# Altium schematic sheet Accelerometer.SchDoc
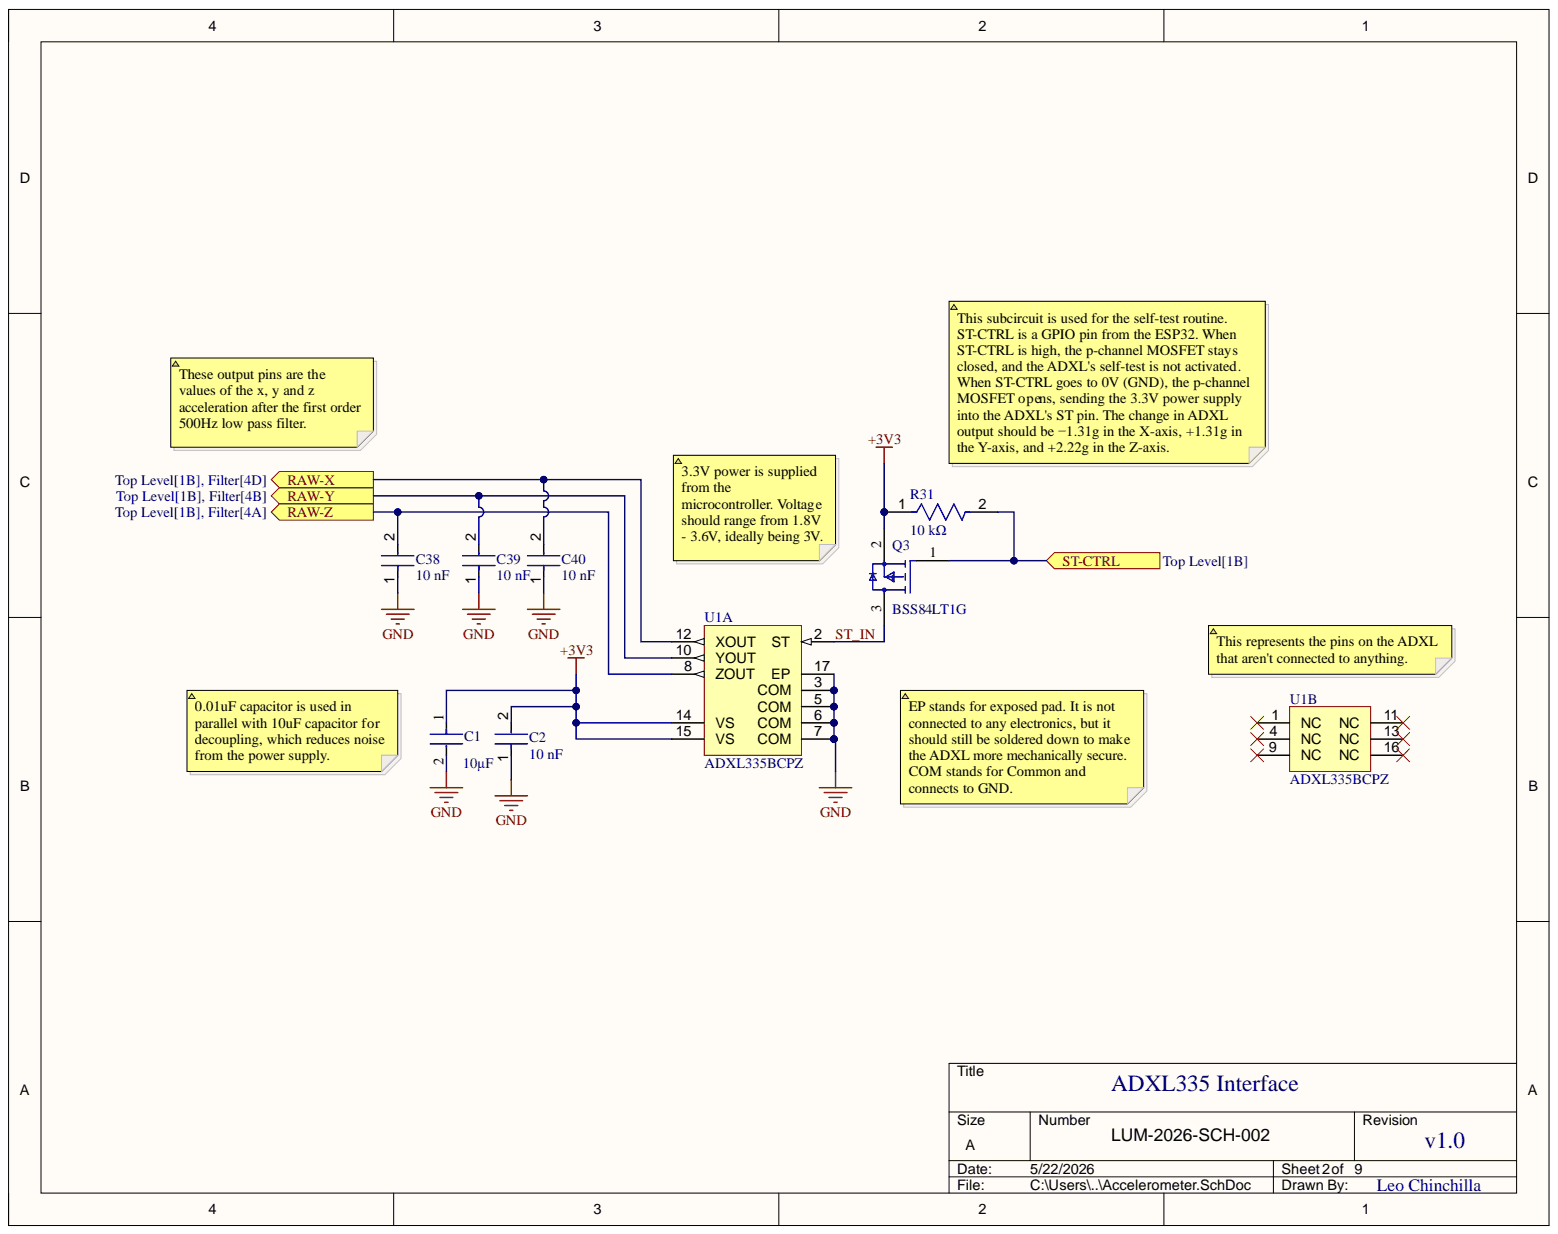
[diagram p0: Accelerometer.SchDoc]

## Parts
C1  Capacitance: 10µF  [FP-CC0603-DC-MFG]  (hidden comment: CC0603KRX5R7BB106)
C2  value 10 nF  [FP-C0603C-CF-MFG]  (hidden comment: C0603C103K3RACTU)
C38  value 10 nF  [FP-C0603C-CF-MFG]  (hidden comment: C0603C103K3RACTU)
C39  value 10 nF  [FP-C0603C-CF-MFG]  (hidden comment: C0603C103K3RACTU)
C40  value 10 nF  [FP-C0603C-CF-MFG]  (hidden comment: C0603C103K3RACTU)
Q3  BSS84LT1G  [FP-318-08-IPC_A]
R31  10 kΩ  [FP-MCT0603-IPC_B]
U1  ADXL335BCPZ  [ADI-CP-16-14_L]  (parts A,B)

## Ports
RAW-X, RAW-Y, RAW-Z, ST-CTRL

## Nets
+3V3: C1.1 C2.2 Q3.2 R31.1 U1.14(VS) U1.15(VS)
GND: C1.2 C2.1 C38.1 C39.1 C40.1 U1.3(COM) U1.5(COM) U1.6(COM) U1.7(COM) U1.17(EP)
RAW-X: C40.2 U1.12(XOUT) ; port RAW-X
RAW-Y: C39.2 U1.10(YOUT) ; port RAW-Y
RAW-Z: C38.2 U1.8(ZOUT) ; port RAW-Z
ST-CTRL: Q3.1 R31.2 ; port ST-CTRL
ST_IN: Q3.3 U1.2(ST)

Not connected: U1 1(NC) 4(NC) 9(NC) 11(NC) 13(NC) 16(NC)

## Library data of the parts
C1 = CMP-00621-81932632-1: CC0603KRX5R7BB106 Multilayer Ceramic Capacitor, 10 uF, 16 V, ± 10%, X5R, 0603 [1608 Metric]; Voltage Rating: 16V; Case/Package: 0603; Component Kind: Standard; Dielectric: X5R; Halogen Free: Halogen Free; Height: 1mm; Lead Free: Lead Free; Library Name: Altium Content Vault; Material: Ceramic; Max Operating Temperature: 85°C; Min Operating Temperature: -55°C; NexarPartId: 81932632; RoHS: Non-Compliant; Tolerance: 10%; Voltage Rating (DC): 16V
C2 C38-C40 = CMP-2006-03040-2: CAP CER 10000PF 25V 10% X7R 0603; Manufacturer: KEMET; Automotive Grade: Grade 1; Capacitance: 10,000 pF; Material: Ceramic; Temperature Coefficient: 30ppm/°C; Tolerance: 0.1; Automotive: Yes; Category: Cap; Component Kind: Standard; ComponentLink2Description: Datasheet; ComponentLink2URL: https://api.kemet.com/component-edge/download/datasheet/C0603C100J5GACAUTO.pdf; Device Class L1: Passive Components; Device Class L2: Capacitors; Device Class L3: Ceramic Capacitors; Digikey Description: CAP CER 10000PF 25V 10% X7R 0603; Height: 0.87mm; Lead Free: Yes; Library Name: Altium Content Vault; Manufacturer Part Number: C0603C103K3RACTU; Mouser Description: Multilayer Ceramic Capacitors MLCC - SMD/SMT 25volts 10000pF X7R 10%; Octopart: https://octopart.com/c0603c103k3ractu-kemet-89103; Package: C0603C-CF; RoHS: TRUE; Temperature: -55°C to +125°C; Temperature Characteristic: X7R; Temperature Range High: +125°C; Temperature Range Low: -55°C; Voltage Rating: 25 V
Q3 = CMP-1058-00762-2: MOSFET P-CH 50V 130MA SOT-23; Current Rating: -130mA; Drain to Source Resistance: 10R; Element Configuration: Single; Fall Time: 1ns; Gate to Source Voltage (Vgs): 20V; Input Capacitance: 30pF; Max Power Dissipation: 225mW; Nominal Vgs: 2V; Number of Elements: 1; Threshold Voltage: -2V; Turn-Off Delay Time: 16ns; Turn-On Delay Time: 3.6ns; Voltage Rating (DC): -50V; Case/Package: SOT-23-3; Component Kind: Standard; Contact Plating: Tin; Continuous Drain Current (ID): 130mA; Drain to Source Breakdown Voltage: 50V; Drain to Source Voltage (Vdss): 50V; Halogen Free: Halogen Free; Height: 0.04inch; Lead Free: Lead Free; Length: 0.119inch; Library Name: Altium Content Vault; Max Operating Temperature: 150°C; Min Operating Temperature: -55°C; Number of Pins: 3; On-State Resistance: 10R; Package Quantity: 1; Packaging: Tape and Reel; Power Dissipation: 225mW; Radiation Hardening: No; Rds On Max: 10R; REACH SVHC: No SVHC; Rise Time: 1ns; Width: 0.055inch
R31 = CMP-02407-001090-1: RES SMD 100 OHM 1% 1/8W 0603; Component Kind: Standard; Library Name: Altium Content Vault
U1 = CMP-0885-00046-1: Small, Low Power, 3-Axis +/-3 g Accelerometer with Analog Output, 1.6 KHz BW, Industrial, 16-pin QFN, Tray; Manufacturer: Analog Devices; # of Axes: 3; -3dB Bandwidth: 1.6 KHz; Sensitivity: 300 mV/g; Supply Current: 350 uA; Voltage Supply (V): 1.8 to 3.6; Code_JEDEC: MO-220-WGGD; Component Kind: Standard; ComponentLink1Description: Manufacturer URL; ComponentLink1URL: http://www.analog.com/en/index.html; ComponentLink2Description: Datasheet; ComponentLink2URL: http://www.analog.com/static/imported-files/data_sheets/ADXL335.pdf; ComponentLink3Description: Package Specification; ComponentLink3URL: http:/www.analog.com/static/imported-files/packages/PKG_PDF/LFCSP(CP)/CP-16/CP_16_14.pdf; ComponentLink4Description: Product URL; ComponentLink4URL: http://www.analog.com/en/mems-sensors/mems-inertial-sensors/adxl335/products/product.html; DatasheetVersion: Rev. B; Library Name: Altium Content Vault; Mounting Technology: Surface Mount; Output Type: Analog; PackageDescription: 16-Pin Lead Frame Chip Scale Package, 4 x 4 mm Body, 0.65 mm Pitch; PackageReference: CP-16-14; PackageVersion: 051909-A, 05/2009; Packing: Tray; PartNumber: ADXL335BCPZ
